# Altium schematic sheet CPU - LPDDR4.SchDoc
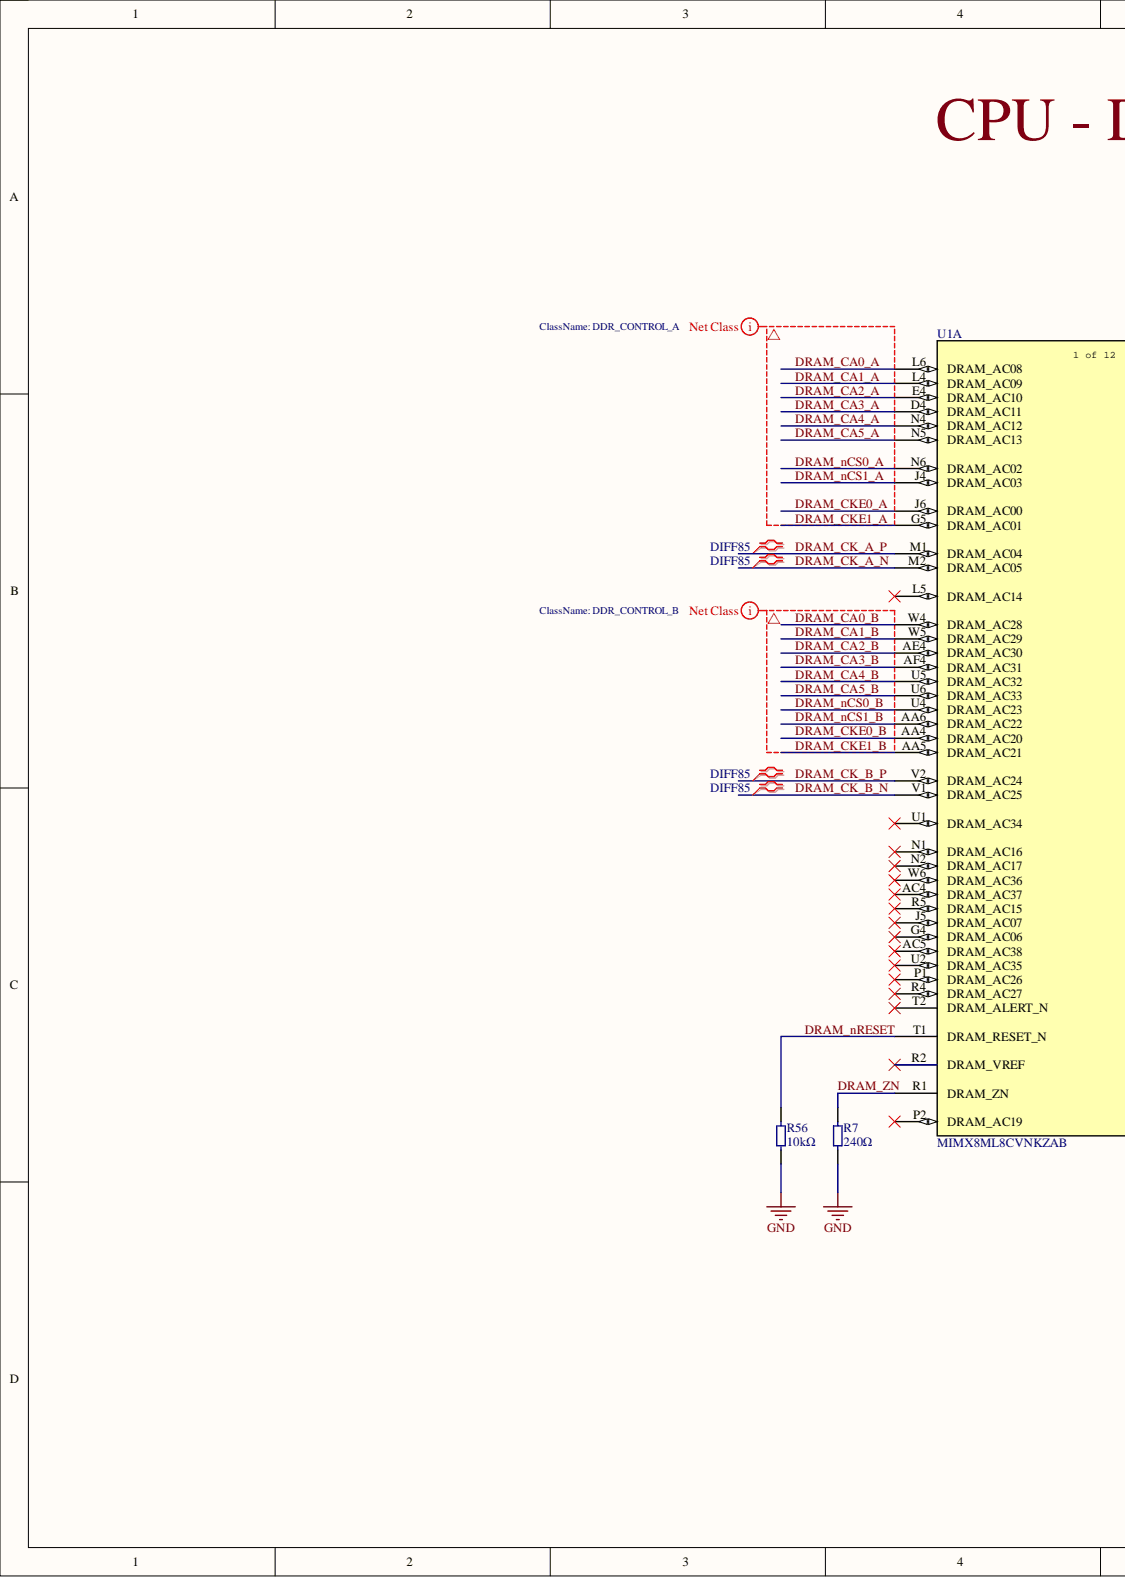
[diagram p0: CPU - LPDDR4.SchDoc, left]
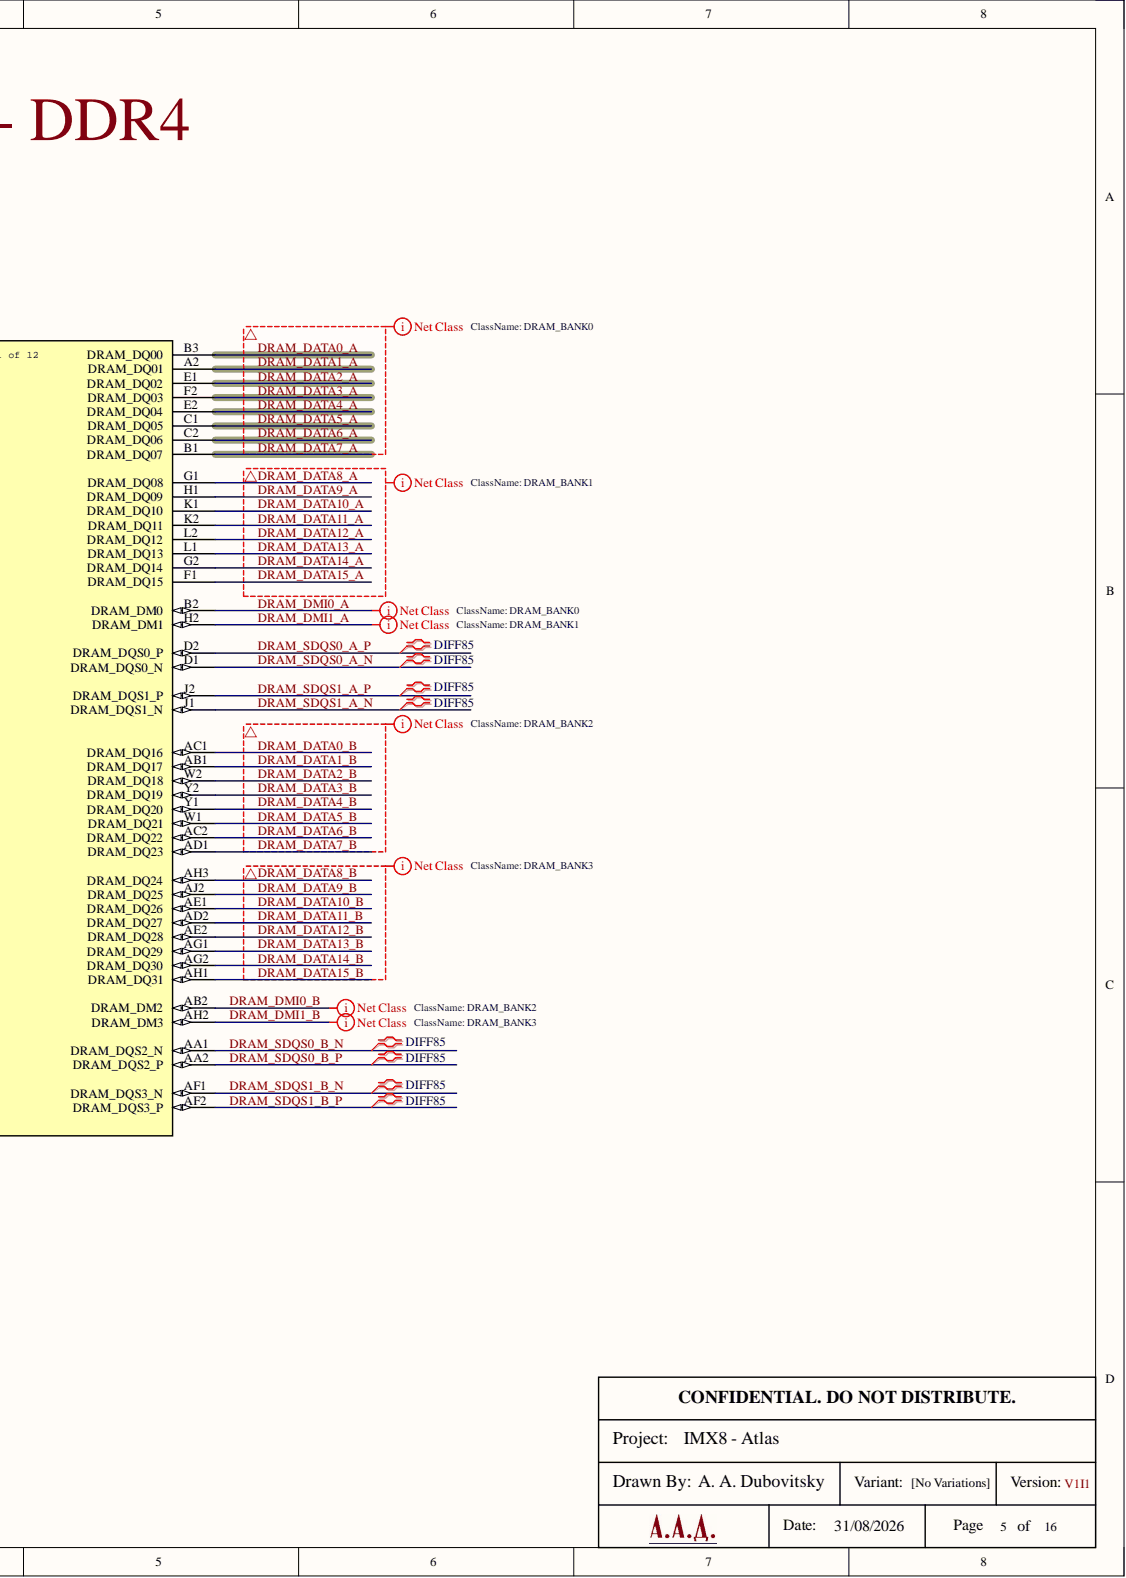
[diagram p1: CPU - LPDDR4.SchDoc, right]

## Parts
R7  240Ω  [RES 0201_0603]
R56  10kΩ  [RES 0201_0603]
U1  MIMX8ML8CVNKZAB  [BGA548C50P29X29_1500X1500X148]  (part A)

## Nets
GND: R7.2 R56.1
DRAM_CA0_A: U1.L6(DRAM_AC08)
DRAM_CA0_B: U1.W4(DRAM_AC28)
DRAM_CA1_A: U1.L4(DRAM_AC09)
DRAM_CA1_B: U1.W5(DRAM_AC29)
DRAM_CA2_A: U1.E4(DRAM_AC10)
DRAM_CA2_B: U1.AE4(DRAM_AC30)
DRAM_CA3_A: U1.D4(DRAM_AC11)
DRAM_CA3_B: U1.AF4(DRAM_AC31)
DRAM_CA4_A: U1.N4(DRAM_AC12)
DRAM_CA4_B: U1.U5(DRAM_AC32)
DRAM_CA5_A: U1.N5(DRAM_AC13)
DRAM_CA5_B: U1.U6(DRAM_AC33)
DRAM_CK_A_N: U1.M2(DRAM_AC05)
DRAM_CK_A_P: U1.M1(DRAM_AC04)
DRAM_CK_B_N: U1.V1(DRAM_AC25)
DRAM_CK_B_P: U1.V2(DRAM_AC24)
DRAM_CKE0_A: U1.J6(DRAM_AC00)
DRAM_CKE0_B: U1.AA4(DRAM_AC20)
DRAM_CKE1_A: U1.G5(DRAM_AC01)
DRAM_CKE1_B: U1.AA5(DRAM_AC21)
DRAM_DATA0_A: U1.B3(DRAM_DQ00)
DRAM_DATA0_B: U1.AC1(DRAM_DQ16)
DRAM_DATA1_A: U1.A2(DRAM_DQ01)
DRAM_DATA1_B: U1.AB1(DRAM_DQ17)
DRAM_DATA2_A: U1.E1(DRAM_DQ02)
DRAM_DATA2_B: U1.W2(DRAM_DQ18)
DRAM_DATA3_A: U1.F2(DRAM_DQ03)
DRAM_DATA3_B: U1.Y2(DRAM_DQ19)
DRAM_DATA4_A: U1.E2(DRAM_DQ04)
DRAM_DATA4_B: U1.Y1(DRAM_DQ20)
DRAM_DATA5_A: U1.C1(DRAM_DQ05)
DRAM_DATA5_B: U1.W1(DRAM_DQ21)
DRAM_DATA6_A: U1.C2(DRAM_DQ06)
DRAM_DATA6_B: U1.AC2(DRAM_DQ22)
DRAM_DATA7_A: U1.B1(DRAM_DQ07)
DRAM_DATA7_B: U1.AD1(DRAM_DQ23)
DRAM_DATA8_A: U1.G1(DRAM_DQ08)
DRAM_DATA8_B: U1.AH3(DRAM_DQ24)
DRAM_DATA9_A: U1.H1(DRAM_DQ09)
DRAM_DATA9_B: U1.AJ2(DRAM_DQ25)
DRAM_DATA10_A: U1.K1(DRAM_DQ10)
DRAM_DATA10_B: U1.AE1(DRAM_DQ26)
DRAM_DATA11_A: U1.K2(DRAM_DQ11)
DRAM_DATA11_B: U1.AD2(DRAM_DQ27)
DRAM_DATA12_A: U1.L2(DRAM_DQ12)
DRAM_DATA12_B: U1.AE2(DRAM_DQ28)
DRAM_DATA13_A: U1.L1(DRAM_DQ13)
DRAM_DATA13_B: U1.AG1(DRAM_DQ29)
DRAM_DATA14_A: U1.G2(DRAM_DQ14)
DRAM_DATA14_B: U1.AG2(DRAM_DQ30)
DRAM_DATA15_A: U1.F1(DRAM_DQ15)
DRAM_DATA15_B: U1.AH1(DRAM_DQ31)
DRAM_DMI0_A: U1.B2(DRAM_DM0)
DRAM_DMI0_B: U1.AB2(DRAM_DM2)
DRAM_DMI1_A: U1.H2(DRAM_DM1)
DRAM_DMI1_B: U1.AH2(DRAM_DM3)
DRAM_nCS0_A: U1.N6(DRAM_AC02)
DRAM_nCS0_B: U1.U4(DRAM_AC23)
DRAM_nCS1_A: U1.J4(DRAM_AC03)
DRAM_nCS1_B: U1.AA6(DRAM_AC22)
DRAM_nRESET: R56.2 U1.T1(DRAM_RESET_N)
DRAM_SDQS0_A_N: U1.D1(DRAM_DQS0_N)
DRAM_SDQS0_A_P: U1.D2(DRAM_DQS0_P)
DRAM_SDQS0_B_N: U1.AA1(DRAM_DQS2_N)
DRAM_SDQS0_B_P: U1.AA2(DRAM_DQS2_P)
DRAM_SDQS1_A_N: U1.J1(DRAM_DQS1_N)
DRAM_SDQS1_A_P: U1.J2(DRAM_DQS1_P)
DRAM_SDQS1_B_N: U1.AF1(DRAM_DQS3_N)
DRAM_SDQS1_B_P: U1.AF2(DRAM_DQS3_P)
DRAM_ZN: R7.1 U1.R1(DRAM_ZN)

Not connected: U1 AC4(DRAM_AC37) AC5(DRAM_AC38) G4(DRAM_AC06) J5(DRAM_AC07) L5(DRAM_AC14) N1(DRAM_AC16) N2(DRAM_AC17) P1(DRAM_AC26) P2(DRAM_AC19) R2(DRAM_VREF) R4(DRAM_AC27) R5(DRAM_AC15) T2(DRAM_ALERT_N) U1(DRAM_AC34) U2(DRAM_AC35) W6(DRAM_AC36)

## Library data of the parts
R7 = C155745: Res. 240Ω 25V 50mW ±1% 0201; Component Kind: Standard; Library Name: Atlas - Symbols.SchLib
R56 = C138117: Res. 10kΩ 25V 50mW ±5% 0201; Component Kind: Standard; Library Name: Atlas - Symbols.SchLib
U1 = MIMX8ML8CVNKZAB: IC ARM MCU; Manufacturer_Name: NXP; Component Kind: Standard; Manufacturer_Part_Number: MIMX8ML8CVNKZAB; RefDes: RefDes; Type: Type; Copyright: Copyright (C) 2026 Ultra Librarian. All rights reserved.; Library Name: Atlas - Symbols.SchLib
